# Altium schematic sheet Power.SchDoc
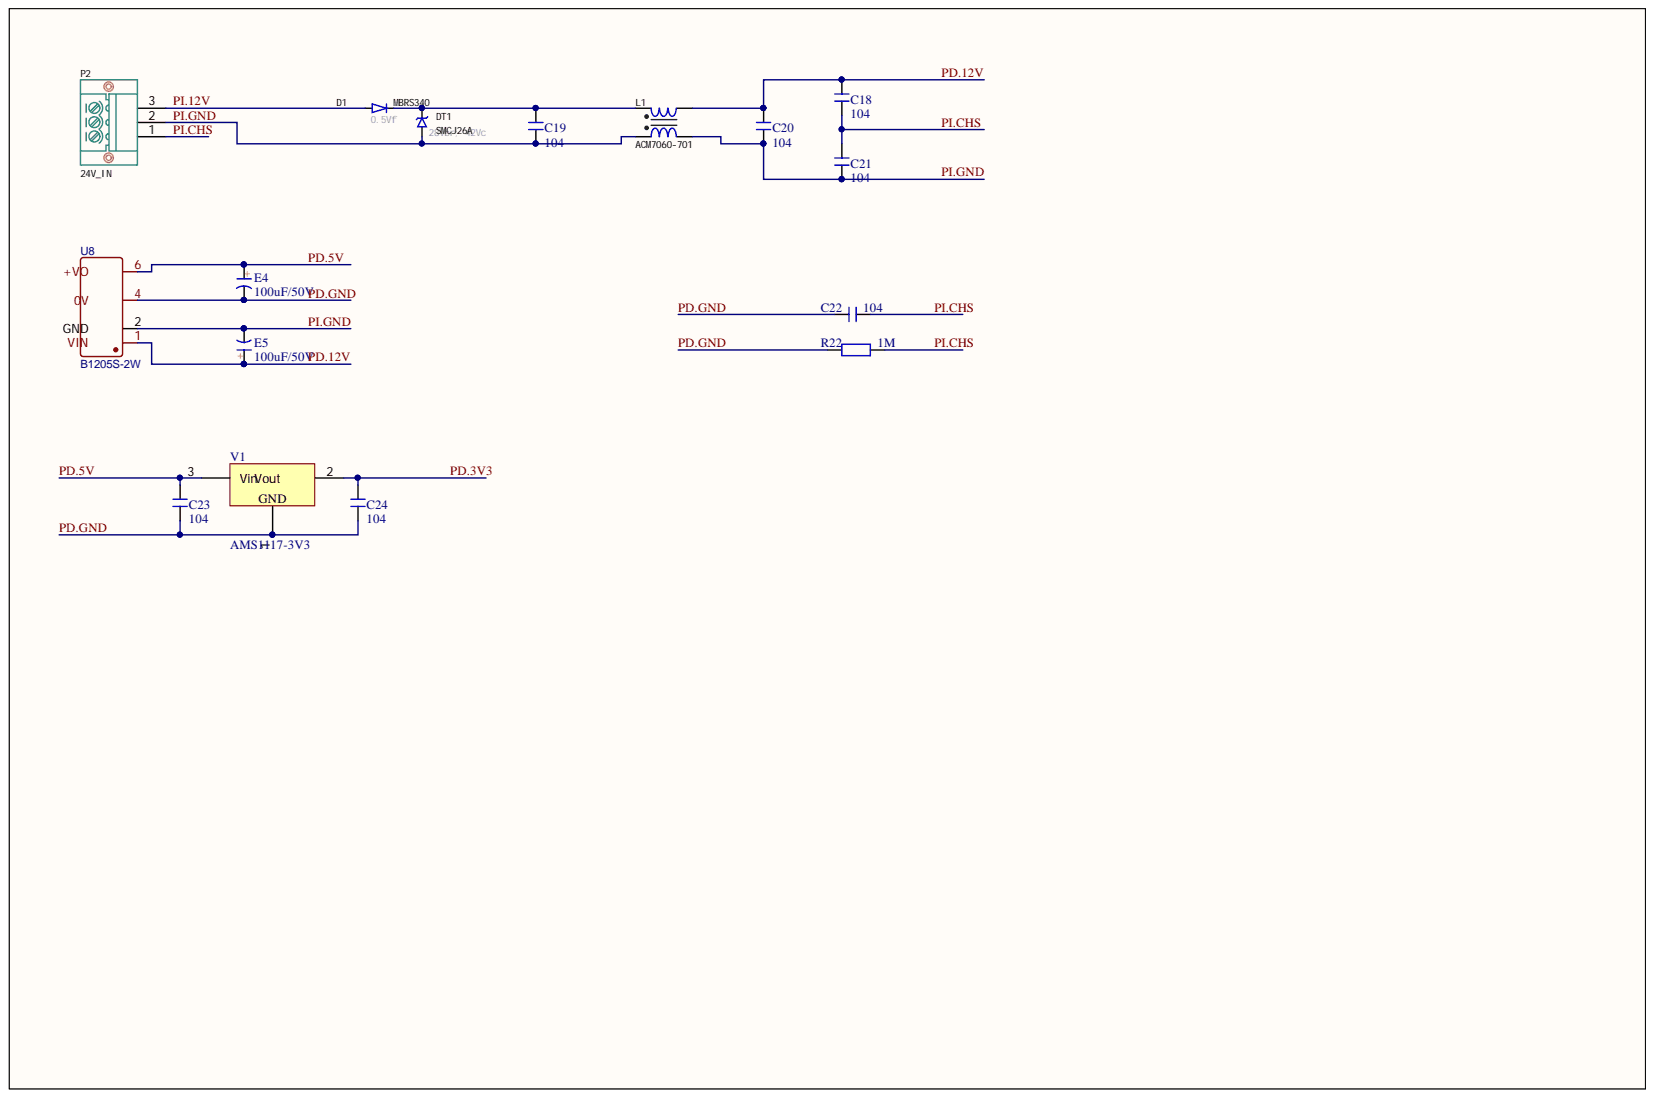
[diagram p0: Power.SchDoc]

## Parts
C18  104  [C 1210]
C19  104  [C 1210]
C20  104  [C 1210]
C21  104  [C 1210]
C22  104  [C 1210]
C23  104  [C 1210]
C24  104  [C 1210]
D1  MBRS340  01_INF: 0.5Vf  [D-SMC]
DT1  SMCJ26A  [D-SMC]
E4  100uF/50V  [CM E(8*10.2)]
E5  100uF/50V  [CM E(8*10.2)]
L1  ACM7060-701  [TDK_Choke_ACM7060]
P2  24V_IN  [C00170]
R22  1M  [R 1210]
U8  B1205S-2W  [B1205S-2W]
V1  AMS1117-3V3  [SOT223_L]

## Nets
PD.3V3: C24.1 V1.2
PD.5V: C23.1 E4.1 U8.6 V1.3
PD.12V: C18.1 C20.2 E5.1 L1.A2 U8.1
PD.GND: C22.1 C23.2 C24.2 E4.2 R22.1 U8.4 V1.1
PI.12V: D1.1 P2.3
PI.CHS: C18.2 C21.1 C22.2 P2.1 R22.2
PI.GND: C19.1 C20.1 C21.2 DT1.1 E5.2 L1.B1 L1.B2 P2.2 U8.2
NetC19_2: C19.2 D1.2 DT1.2 L1.A1

## Library data of the parts
C18-C24 = C: 无极性贴片电容; 版权归属: 源创客; BOM名称: 无极性贴片电容; Component Kind: Standard; Library Name: 电阻-电容-电感.SchLib; 学习资源: www.ooocke.com
D1 = Diode: D-SMC-MBRS340; 02_CMT: OnSemi MBRS340T3G; 10_Code: C40326; 11_Name: 贴装元件_肖特基二极管_MBRS340_SMC; Component Kind: Standard; Library Name: TKAI0163WP01-01.IntLib
DT1 = Diode_TVS_UniDirectional: DT-SMC-SMCJ26A; 01_INF: 28Vbr; 42Vc; 02_CMT: LittleFuse SMCJ26A; TM: GFE; 10_Code: C224046; 11_Name: 贴装元件_单向TVS_SMC_26V; Component Kind: Standard; Library Name: TKAI0163WP01-01.IntLib
E4 E5 = C-CM: 贴片电解电容; 版权归属: 源创客; BOM名称: 贴片电解电容; Component Kind: Standard; Library Name: 电阻-电容-电感.SchLib; 学习资源: www.ooocke.com
L1 = IND_CHOKE: L-ACM7060-701; 10_Code: C78246; 11_Name: 贴装电感_共模_ACM7060-701-2PL-TL01; Component Kind: Standard; Library Name: TKAI0163WP01-01.IntLib
P2 = C00170_绿座_弯_针_单排_3.50_3P_紧固: P-插装绿座_弯_针_单排_3.5_3P_紧固; 11_Name: 插装绿座_弯_针_单排_3.5_3P_紧固; Component Kind: Standard; Library Name: TKAI0163WP01-01.IntLib
R22 = R: 贴片电阻; 版权归属: 源创客; BOM名称: 贴片电阻; Component Kind: Standard; Library Name: 电阻-电容-电感.SchLib; 学习资源: www.ooocke.com
U8 = B1205S-2W: BOM_Manufacturer: JETEKPS; BOM_Paste Type: expand; Contributor: LCSC; BOM_Manufacturer Part: B1205S-2W; BOM_Supplier: LCSC; BOM_Supplier Part: C442553; Component Kind: Standard; spicePre: U; spiceSymbolName: B1205S-2W
V1 = AMS1117: 三端稳压芯片; BOM名称: 稳压芯片; 版权归属: 源创客; Component Kind: Standard; Library Name: IC芯片.SchLib; 学习资源: www.ooocke.com
